# Altium schematic sheet PowerSupply.SchDoc
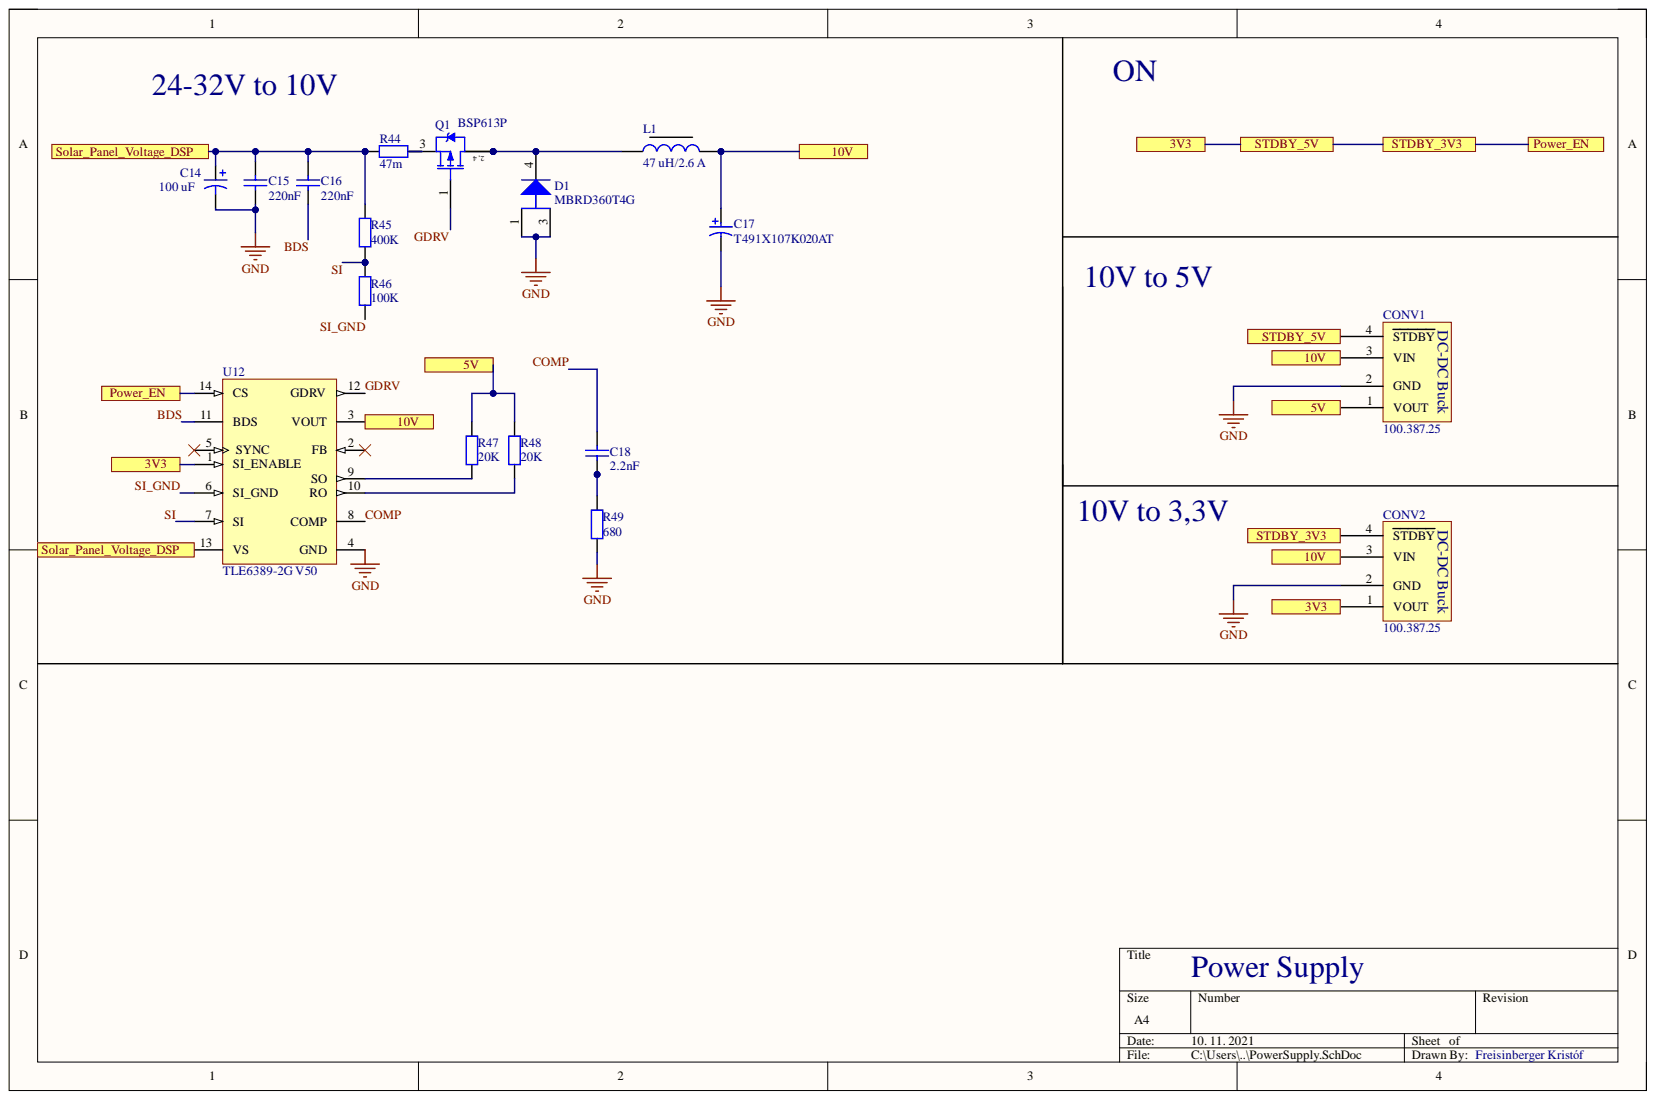
[diagram p0: PowerSupply.SchDoc]

## Parts
C14  100 uF
C15  220nF  [FP_0805_CAP_085]
C16  220nF  [FP_0805_CAP_085]
C17  T491X107K020AT  [KEMT-7343-43_L]
C18  2.2nF  [FP_0805_CAP_085]
CONV1  Reference: 100.387.25  [FP_CONN4_TUSKE_254MM]  (hidden comment: DCDC_converter_4pin)
CONV2  Reference: 100.387.25  [FP_CONN4_TUSKE_254MM]  (hidden comment: DCDC_converter_4pin)
D1  MBRD360T4G  [ONSC-DPAK-3-369C-01_V]
L1  47 uH/2.6 A  [Tekercs_B82479-A1473-M - duplicate]
Q1  BSP613P  [INF-PG-SOT223_SC-73_M]
R44  47m  [FP_0603_RES]
R45  400K  [FP_0603_RES]
R46  100K  [FP_0603_RES]
R47  20K  [FP_0603_RES]
R48  20K  [FP_0603_RES]
R49  680  [FP_0603_RES]
U12  TLE6389-2G V50  [INF-PG-DSO-14-1_M]

## Ports
3V3, 5V, 10V, Power_EN, Solar_Panel_Voltage_DSP, STDBY_3V3, STDBY_5V

## Nets
GND: C14.N C15.1 C17.2 CONV1.2(GND) CONV2.2(GND) D1.1 D1.3 R49.1 U12.4(GND)
3V3: CONV1.4(STDBY) CONV2.1(VOUT) CONV2.4(STDBY) U12.1(SI_ENABLE) U12.14(CS) ; port 3V3, port Power_EN, port STDBY_3V3, port STDBY_5V
5V: CONV1.1(VOUT) R47.2 R48.2 ; port 5V
10V: C17.1 CONV1.3(VIN) CONV2.3(VIN) L1.2 U12.3(VOUT) ; port 10V
BDS: C16.1 U12.11(BDS)
COMP: C18.2 U12.8(COMP)
GDRV: Q1.1 U12.12(GDRV)
SI: R45.1 R46.2 U12.7(SI)
SI_GND: R46.1 U12.6(SI_GND)
Solar_Panel_Voltage_DSP: C14.P C15.2 C16.2 R44.2 R45.2 U12.13(VS) ; port Solar_Panel_Voltage_DSP
NetC18_1: C18.1 R49.2
NetD1_4: D1.4 L1.1 Q1.2 Q1.4
NetQ1_3: Q1.3 R44.1
NetR47_1: R47.1 U12.9(SO)
NetR48_1: R48.1 U12.10(RO)

Not connected: U12 2(FB) 5(SYNC)

## Library data of the parts
C14 = CAP_ELEC_100uF_ECA2AAM101X: PANASONIC ELECTRONIC COMPONENTS         ECA2AAM101X             Electrolytic Capacitor, 100 F, 100 V, AM Series,  20%, Radial Leaded, 10 mm; Capacitance: 100uF; Dielectric Material: Aluminium; Life (Hours): 2000Hour; Ripple Current: 350mA; Voltage: 100V; Case/Package: Radial; Category: Aluminum Electrolytic Capacitors; Component Kind: Standard; ComponentLink1Description: https://datasheet.ciiva.com/6001/1700589-6001627.pdf?src-supplier=Farnell; ComponentLink1URL: https://datasheet.ciiva.com/6001/1700589-6001627.pdf?src-supplier=Farnell; Diameter: 10mm; Height: 16mm; Lead Pitch: 5mm; Manufacturer: Panasonic; Manufacturer Part Number: ECA2AAM101X; Max Operating Temperature: 85°C; Min Operating Temperature: -40°C; Number of Pins: 2; REACH SVHC: No SVHC; Ripple Current (AC): 350mA; RoHS: Yes; Stock 1: 1440; Supplier 1: RSComponents; Termination: Radial; Tolerance: 20%; Voltage Rating: 100V; Voltage Rating (DC): 100V; Library Name: Napelem_Inverter.SchLib
C15 C16 C18 = CAP: Capacitor; Component Kind: Standard; Library Name: Napelem_Inverter.SchLib
  C15 C16: Value: 220nF
  C18: Value: 2.2nF
C17 = CMP-2000-07204-1: Tantalum Surface Mount Capacitor, 100 uF, 25 V, -55 to 125 degC, 2-Pin Molded, RoHS, Tape and Reel; Manufacturer: Kemet; Manufacturer Size Code: X; Capacitance: 100µF; EDA / CAD Models: DownloadfromAcceleratedDesigns; ESR (Equivalent Series Resistance): 300mOhm; Lifetime @ Temp.: 2000Hrs@85°C; Online Catalog: T491Series; Other Names: 399-8410-6; Product Training Modules: CapacitorBasics-TypicalUsesforCapacitors; Tolerance: ±10%; Voltage - Rated: 25V; Category: Capacitors; Component Kind: Standard; Datasheet URL: https://ciiva.com/datasheet-click-3798150?src=ciiva&sig=eb1f20b4; Datasheet Version: 12/2015; Family: TantalumCapacitors; Features: GeneralPurpose; Height - Seated (Max): 0.169"(4.30mm); Lead Spacing: -; Library Name: Altium Content Vault; Manufacturer URL: http://www.kemet.com/; Mounting Technology: SurfaceMount; Mounting Type: SurfaceMount; Operating Temperature: -55°C~125°C; Package / Case: 2917(7343Metric); Package Description: 2-Pin Molded Capacitor, Body 7.3 x 4.3 mm; Package Reference: Molded; Packaging: Digi-Reel®; Series: T491; Size / Dimension: 0.287"Lx0.169"W(7.30mmx4.30mm); Standard Package: 1; Type: Molded
CONV1 CONV2 = DCDC_converter_4pin: Name: STDN-3A24-ADJ; Component Kind: Standard; Supplier: hestore; Library Name: RobonAUT_2021feb.SchLib
D1 = CMP-1055-00192-1: SWITCHMODE(TM) Power Rectifier, 3-Pin DPAK, Pb-Free, Tape and Reel; Manufacturer: On Semiconductor; Configuration: Single; IFSM Max (A): 75; Component Kind: Standard; ComponentLink1Description: Manufacturer URL; ComponentLink1URL: http://www.onsemi.com/; ComponentLink2Description: Datasheet; ComponentLink2URL: http://www.onsemi.com/pub_link/Collateral/MBRD320-D.PDF; ComponentLink3Description: Package Specification; ComponentLink3URL: http://www.onsemi.cn/pub_link/Collateral/369C.PDF; DatasheetVersion: Rev. 8; Library Name: Altium Content Vault; Mounting Technology: Surface Mount; PackageDescription: 3-Pin Flange Mount, Body 6.54 x 6.095 mm, Pitch 2.29 mm; PackageReference: DPAK-3-369C-01; PackageVersion: Rev. E, 02/2014; Packing: Tape and Reel; PartNumber: MBRD360T4G; RoHS: TRUE
L1 = Inductor_B82479A1473M000: Inductor Power Wirewound 47uH 20% 100KHz Ferrite 2.6A 87mOhm DCR Automotive T/R; Manufacturer: TDK EPCOS; DC Resistance (DCR): 87mR; Inductor Type: POWER INDUCTOR; Saturation Current: 4.5A; Shielding: Unshielded; Case/Package: SMD/SMT; Category: Fixed Inductors; Component Kind: Standard; ComponentLink1Description: https://datasheet.ciiva.com/6692/b82479a1-6692885.pdf?src-supplier=Digi-Key; ComponentLink1URL: https://datasheet.ciiva.com/6692/b82479a1-6692885.pdf?src-supplier=Digi-Key; ComponentLink2Description: https://datasheet.ciiva.com/22683/1899175-22683671.pdf?src-supplier=Element14; ComponentLink2URL: https://datasheet.ciiva.com/22683/1899175-22683671.pdf?src-supplier=Element14; ComponentLink3Description: https://datasheet.ciiva.com/22683/1899175-22683672.pdf?src-supplier=Element14; ComponentLink3URL: https://datasheet.ciiva.com/22683/1899175-22683672.pdf?src-supplier=Element14; ComponentLink4Description: https://datasheet.ciiva.com/28652/b82479a1-28652056.pdf?src-supplier=Element14; ComponentLink4URL: https://datasheet.ciiva.com/28652/b82479a1-28652056.pdf?src-supplier=Element14; Core Material: Ferrite; Current Rating: 2.6A; DC Current: 2.6A; Depth: 15.24mm; Height: 7.11mm; Inductance: 47uH; Inductance Tolerance: 20%; Lead Free: Lead Free; Length: 18.54mm; Manufacturer Part Number: B82479A1473M000; Max DC Current: 2.6A; Max Operating Temperature: 150°C; Military Standard: Not; Min Operating Temperature: -55°C; Mount: Surface Mount; Number of Pins: 2; Package Quantity: 250; Packaging: Tape and Reel; REACH SVHC: No SVHC; RMS Current (Irms): 2.6A; RoHS: Yes; RoHS Compliant: Yes; Self Resonant Frequency: 100kHz; Series: B82479A1; Stock 1: 25; Supplier 1: Farnell; Termination: SMD/SMT; Test Frequency: 100kHz; Tolerance: 20%; Weight: 0.105822oz; Width: 0.6inch; Library Name: Napelem_Inverter.SchLib
Q1 = CMP-1099-00021-1: P-Channel SIPMOS Small-Signal-Transistor, -60 V VDS, -2.9 A ID, -55 to 150 degC, PG-SOT223 (SC-73), Reel, Green; Manufacturer: Infineon; Green: TRUE; ID (max): -2.9Â A; IDpuls (max): -11.6Â A; Mode: Enhancement; Polarity: P; Pto t (max): 1.8Â W; QG: -22Â nC; RDS (on) (max): 130Â mOhm; Rth: 100Â K/W; VDS (max): -60Â V; VGS(th) (max): -4Â V; VGS(th) (min): -2.1Â V; Component Kind: Standard; ComponentLink1Description: Manufacturer URL; ComponentLink1URL: http://www.infineon.com/; ComponentLink2Description: Datasheet; ComponentLink2URL: http://www.infineon.com/dgdl/BSP613P_Rev2.7.pdf?folderId=db3a304314dca38901154a72e3951a65&fileId=db3a30433b47825b013b60ac86a96dc0; ComponentLink3Description: Package Specification; ComponentLink3URL: http://www.infineon.com/dgdl/SOT223-Package_Overview.pdf?folderId=db3a30431936bc4b0119530470b03c3d&fileId=db3a30431936bc4b0119531d40b93c5c; DatasheetVersion: Rev. 2.7; Halogen-free: TRUE; Library Name: Altium Content Vault; Mounting Technology: Surface Mount; PackageDescription: 4-Pin Small Outline Transistor Package, 6.5 x 3.5 mm Body, 2.3 mm Pitch; PackageReference: PG-SOT223 (SC-73); PackageVersion: Apr-08; Packing: Reel; PartNumber: BSP613P; Technology: SIPMOS
R44-R49 = RES: Resistor; Component Kind: Standard; Library Name: Napelem_Inverter.SchLib
  R44: Value: 47m
  R45: Value: 400K
  R46: Value: 100K
  R47 R48: Value: 20K
  R49: Value: 680
U12 = CMP-1093-00004-1: Step-Down DC/DC Controller, -40 to 150 degC, PG-DSO-14-1, Reel, Green; Manufacturer: Infineon; Green: TRUE; IQ (max): 2,300 mA; Iq (typ): 130 uA; Output: Buck Converter; Tolerance: 3%; VQ (max): 5V; Component Kind: Standard; ComponentLink1Description: Manufacturer URL; ComponentLink1URL: http://www.infineon.com/; ComponentLink2Description: Datasheet; ComponentLink2URL: http://www.infineon.com/dgdl/TLE6389-2-3_DS_21.pdf?folderId=db3a304412b407950112b408e8c90004&fileId=db3a304412b407950112b43641bf65a6&location=en.Products.Power_Management_ICs.DC_DC.DC_DC-Converter__Automotive_.DATASHEET.TLE6389-2-3_DS_21.pdf; ComponentLink3Description: Package Specification; ComponentLink3URL: http://www.infineon.com/dgdl/DCDC-Controller-IFX80471-Data-Sheet-V10-Infineon.pdf?folderId=db3a304314dca3890115039cf70d0bf0&fileId=db3a30432e398416012e487ec6e42599; DatasheetVersion: Rev. 2.1; Halogen-free: TRUE; Library Name: Altium Content Vault; Mounting Technology: Surface Mount; PackageDescription: 14-Pin Plastic (Green) Dual Small Outline Package, 8.65 x 3.9 mm Body, 1.27 mm Pitch; PackageReference: PG-DSO-14-1; PackageVersion: Apr-11; Packing: Reel; PartNumber: TLE6389-2G V50
